# Altium schematic sheet Controller.SchDoc(Controller)
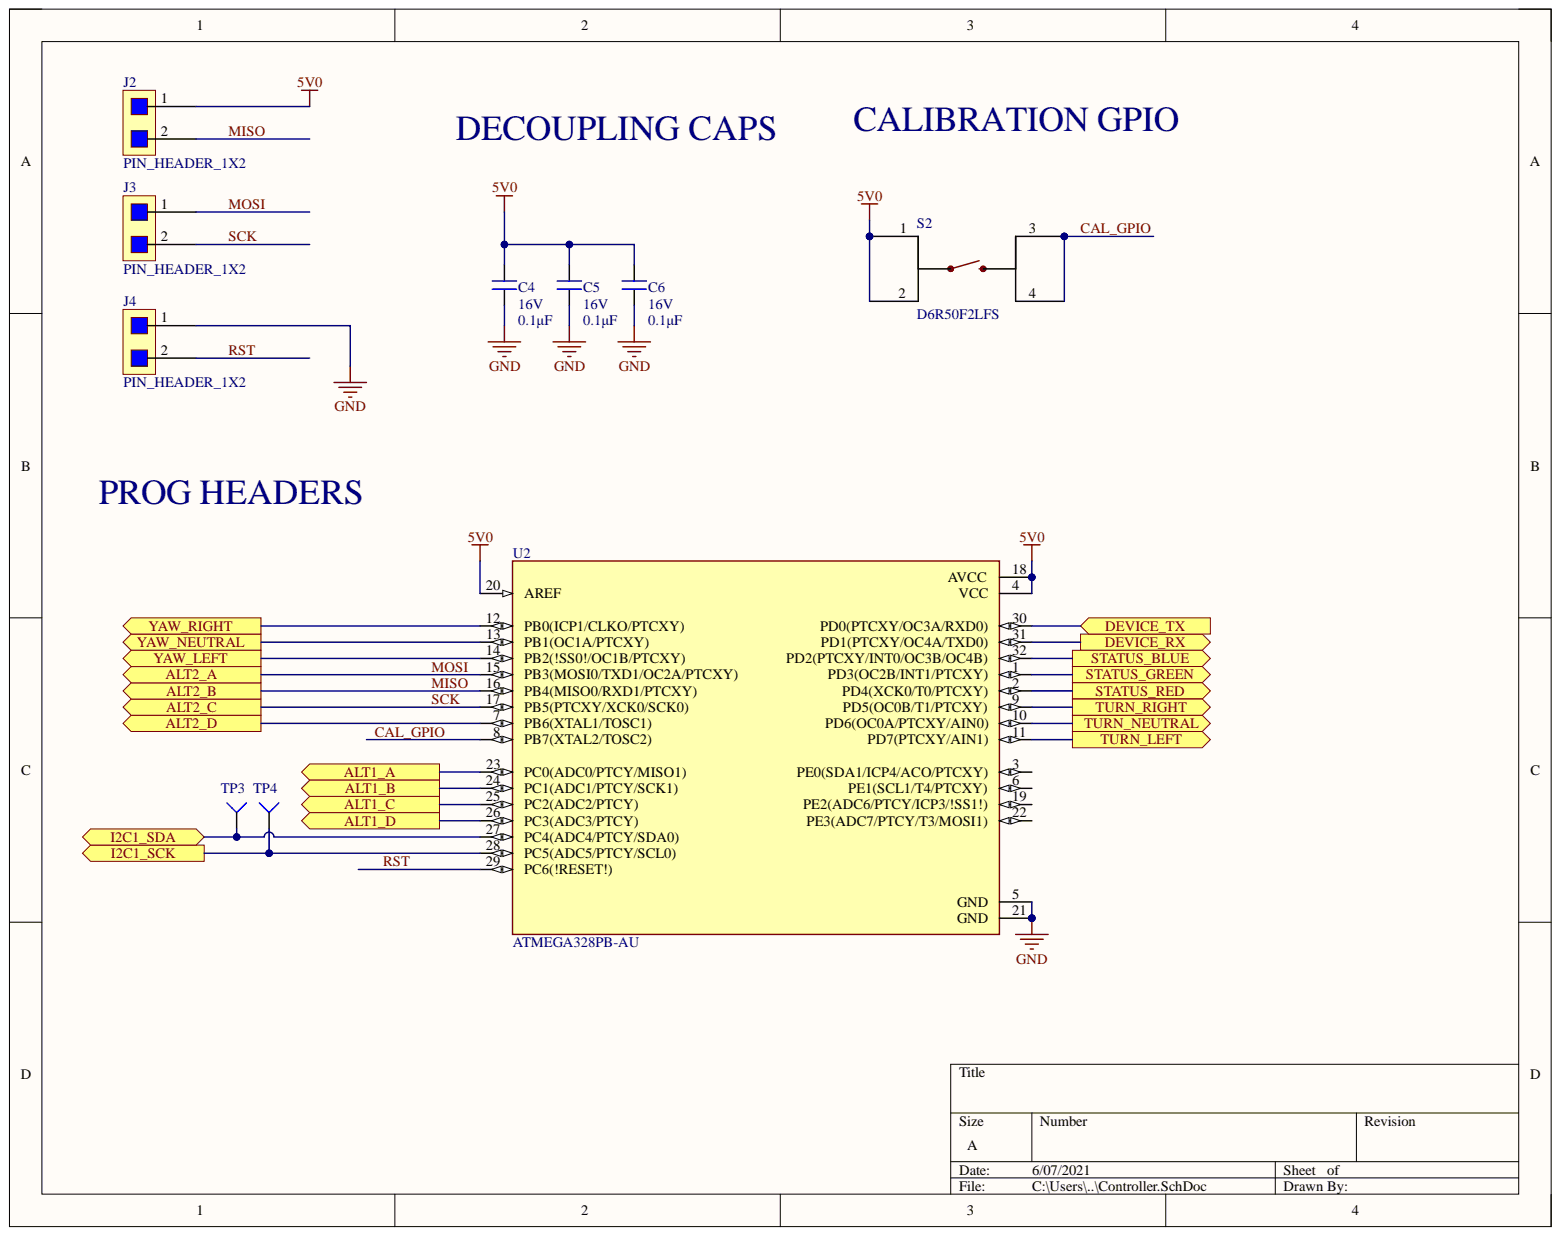
[diagram p0: Controller.SchDoc]

## Parts
C4  value 0.1μF  Voltage Rating: 16V  [CAP0603]  (hidden comment: CAP_0.1uF_16V_0603)
C5  value 0.1μF  Voltage Rating: 16V  [CAP0603]  (hidden comment: CAP_0.1uF_16V_0603)
C6  value 0.1μF  Voltage Rating: 16V  [CAP0603]  (hidden comment: CAP_0.1uF_16V_0603)
J2  PIN_HEADER_1X2  [PIN_HEADER - 1X2]
J3  PIN_HEADER_1X2  [PIN_HEADER - 1X2]
J4  PIN_HEADER_1X2  [PIN_HEADER - 1X2]
S2  Manufacturer Part Number 1: D6R50F2LFS  [D6R]  (hidden comment: SW_MOMENTARY_SPST)
TP3  TESTPT  [TESTPT SQUARE]  (value not drawn)
TP4  TESTPT  [TESTPT SQUARE]  (value not drawn)
U2  ATMEGA328PB-AU  [QFP80P900X900X120-32N]

## Ports
ALT1_A, ALT1_B, ALT1_C, ALT1_D, ALT2_A, ALT2_B, ALT2_C, ALT2_D, DEVICE_RX, DEVICE_TX, I2C1_SCK, I2C1_SDA, STATUS_BLUE, STATUS_GREEN, STATUS_RED, TURN_LEFT, TURN_NEUTRAL, TURN_RIGHT, YAW_LEFT, YAW_NEUTRAL, YAW_RIGHT

## Nets
5V0: C4.2 C5.2 C6.2 J2.1 S2.1 S2.2 U2.4(VCC) U2.18(AVCC) U2.20(AREF)
GND: C4.1 C5.1 C6.1 J4.1 U2.5(GND) U2.21(GND)
ALT1_A: U2.23(PC0(ADC0/PTCY/MISO1)) ; port ALT1_A
ALT1_B: U2.24(PC1(ADC1/PTCY/SCK1)) ; port ALT1_B
ALT1_C: U2.25(PC2(ADC2/PTCY)) ; port ALT1_C
ALT1_D: U2.26(PC3(ADC3/PTCY)) ; port ALT1_D
ALT2_D: U2.7(PB6(XTAL1/TOSC1)) ; port ALT2_D
CAL_GPIO: S2.3 S2.4 U2.8(PB7(XTAL2/TOSC2))
DEVICE_RX: U2.31(PD1(PTCXY/OC4A/TXD0)) ; port DEVICE_RX
DEVICE_TX: U2.30(PD0(PTCXY/OC3A/RXD0)) ; port DEVICE_TX
I2C1_SCK: TP4.1 U2.28(PC5(ADC5/PTCY/SCL0)) ; port I2C1_SCK
I2C1_SDA: TP3.1 U2.27(PC4(ADC4/PTCY/SDA0)) ; port I2C1_SDA
MISO: J2.2 U2.16(PB4(MISO0/RXD1/PTCXY)) ; port ALT2_B
MOSI: J3.1 U2.15(PB3(MOSI0/TXD1/OC2A/PTCXY)) ; port ALT2_A
RST: J4.2 U2.29(PC6(!RESET!))
SCK: J3.2 U2.17(PB5(PTCXY/XCK0/SCK0)) ; port ALT2_C
STATUS_BLUE: U2.32(PD2(PTCXY/INT0/OC3B/OC4B)) ; port STATUS_BLUE
STATUS_GREEN: U2.1(PD3(OC2B/INT1/PTCXY)) ; port STATUS_GREEN
STATUS_RED: U2.2(PD4(XCK0/T0/PTCXY)) ; port STATUS_RED
TURN_LEFT: U2.11(PD7(PTCXY/AIN1)) ; port TURN_LEFT
TURN_NEUTRAL: U2.10(PD6(OC0A/PTCXY/AIN0)) ; port TURN_NEUTRAL
TURN_RIGHT: U2.9(PD5(OC0B/T1/PTCXY)) ; port TURN_RIGHT
YAW_LEFT: U2.14(PB2(!SS0!/OC1B/PTCXY)) ; port YAW_LEFT
YAW_NEUTRAL: U2.13(PB1(OC1A/PTCXY)) ; port YAW_NEUTRAL
YAW_RIGHT: U2.12(PB0(ICP1/CLKO/PTCXY)) ; port YAW_RIGHT

Not connected: U2 3(PE0(SDA1/ICP4/ACO/PTCXY)) 6(PE1(SCL1/T4/PTCXY)) 19(PE2(ADC6/PTCY/ICP3/!SS1!)) 22(PE3(ADC7/PTCY/T3/MOSI1))

## Library data of the parts
C4-C6 = CAP_0.1uF_16V_0603: CAP CER 0.1UF 16V X7R 0603; Manufacturer 1: Yageo; Manufacturer Part Number 1: CC0603KRX7R7BB104; Package/Footprint: 0603; Component Kind: Standard; Dielectric: X7R; Supplier 1: Digi-Key; Supplier Part Number 1: 311-1088-1-ND; Library Name: SKALE_LIB.SCHLIB
J2-J4 = PIN_HEADER_1X2: Board Connector, 1 Contact(s), 1 Row(s), Male, Straight, Solder Terminal; Manufacturer: Sullins; Manufacturer Part Number: PREC001SAAN-RC; DIN Conformance: No; Number of Contacts: 1; Option: General Purpose; Category: Connectors; Component Kind: Standard; ComponentLink1Description: https://datasheet.ciiva.com:443/pdfs/VipMasterIC/IC/SUCS/SUCS-S-A0005121173/SUCS-S-A0005121173-1.pdf?src-supplier=IHS+Markit; ComponentLink1URL: https://datasheet.ciiva.com:443/pdfs/VipMasterIC/IC/SUCS/SUCS-S-A0005121173/SUCS-S-A0005121173-1.pdf?src-supplier=IHS+Markit; ComponentLink2Description: https://datasheet.ciiva.com/740/zrzczzzszzn-rc-st-11635-b-740844.pdf?src-supplier=Digi-Key; ComponentLink2URL: https://datasheet.ciiva.com/740/zrzczzzszzn-rc-st-11635-b-740844.pdf?src-supplier=Digi-Key; Connector Type: BOARD CONNECTOR; Contact Gender: Male; Filter Feature: No; Flammability Rating: UL94 V-0; IEC Conformance: No; MIL Conformance: No; Mixed Contacts: No; Mount: Board; Mounting Style: STRAIGHT; Number of Rows Loaded: 1; Pricing 1: 1=0.04000, 10=0.03000, 25=0.02800, 50=0.02080, 100=0.01780, 250=0.01724, 500=0.01486 (USD); RoHS: Yes; Stock 1: 16681; Supplier 1: Digi-Key; Supplier Part Number 1: S1012EC-01-ND; Termination: Solder; Library Name: SKALE_LIB.SCHLIB
S2 = SW_MOMENTARY_SPST: SWITCH PUSH SPST-NO 0.1A 32V; Manufacturer 1: ITT C&K; Actuator Length: 7mm; Actuator Type: Round, Button; Current Rating: 100mA; Electrical Life: 250000Cycles; Operating Force: 2.5N; Row Spacing: 5mm; Switch Function: Off-Mom; Throw Configuration: SPST; Component Kind: Standard; Contact Plating: Silver; Max Current Rating: 100mA; Max Voltage Rating (DC): 32V; Number of Terminals: 4; Orientation: Straight; Supplier 1: Digi-Key; Supplier Part Number 1: 401-1993-ND; Terminal Pitch: 5mm; Voltage Rating (DC): 32V; Library Name: SKALE_LIB.SCHLIB
TP3 TP4 = TESTPT SQUARE: Component Kind: Standard; Library Name: SKALE_LIB.SCHLIB
U2 = ATMEGA328PB-AU: RISC Microcontroller, 8-Bit, FLASH, AVR RISC CPU, 20MHz, CMOS, PQFP32; Manufacturer: Microchip; MF: Microchip Technology; Category: Microcontrollers; Component Kind: Standard; ComponentLink1Description: https://datasheet.ciiva.com:443/pdfs/VipMasterIC/IC/MCHP/MCHP-S-A0004276582/MCHP-S-A0004276582-1.pdf?src-supplier=IHS+Markit; ComponentLink1URL: https://datasheet.ciiva.com:443/pdfs/VipMasterIC/IC/MCHP/MCHP-S-A0004276582/MCHP-S-A0004276582-1.pdf?src-supplier=IHS+Markit; ComponentLink2Description: http://www.farnell.com/datasheets/2915218.pdf?src-supplier=Farnell; ComponentLink2URL: http://www.farnell.com/datasheets/2915218.pdf?src-supplier=Farnell; ComponentLink3Description: http://www.farnell.com/datasheets/2915218.pdf?src-supplier=Newark; ComponentLink3URL: http://www.farnell.com/datasheets/2915218.pdf?src-supplier=Newark; ComponentLink4Description: http://www.microchip.com/mymicrochip/filehandler.aspx?ddocname=en589666&src-supplier=Digi-Key; ComponentLink4URL: http://www.microchip.com/mymicrochip/filehandler.aspx?ddocname=en589666&src-supplier=Digi-Key; Digi-Key_Part_Number: ATMEGA328PB-AU-ND; Digi-Key_Purchase_URL: https://www.digikey.com/product-detail/en/microchip-technology/ATMEGA328PB-AU/ATMEGA328PB-AU-ND/5638812?utm_source=snapeda&utm_medium=aggregator&utm_campaign=symbol; Manufacturer Part Number: ATMEGA328PB-AU; MP: ATMEGA328PB-AU; Package: TQFP-32 Microchip Technology; Pricing 1: 1=1.47000, 25=1.35320, 100=1.23200 (USD); REACH SVHC: Yes; RoHS: Yes; RoHS Compliant: Yes; SnapEDA_Link: https://www.snapeda.com/parts/ATMEGA328PB-AU/Microchip/view-part/4478285/?ref=snap; Supplier 1: Digi-Key; Supplier Part Number 1: ATMEGA328PB-AU-ND; Technology: CMOS; Library Name: SKALE_LIB.SCHLIB
